# Altium schematic sheet RAM.SchDoc
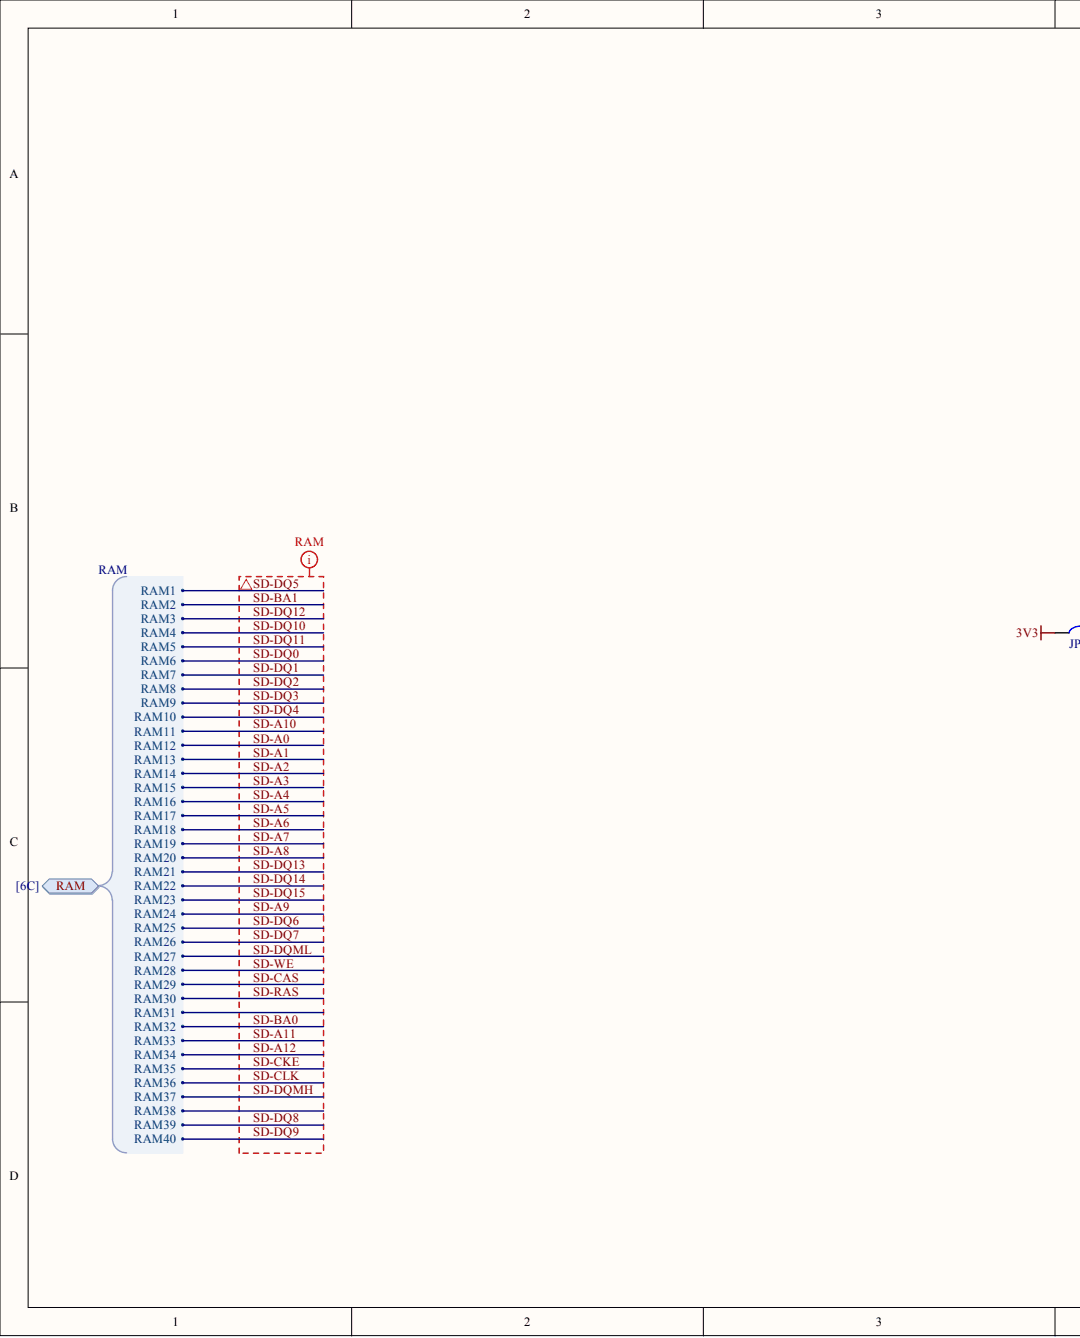
[diagram p0: RAM.SchDoc, left]
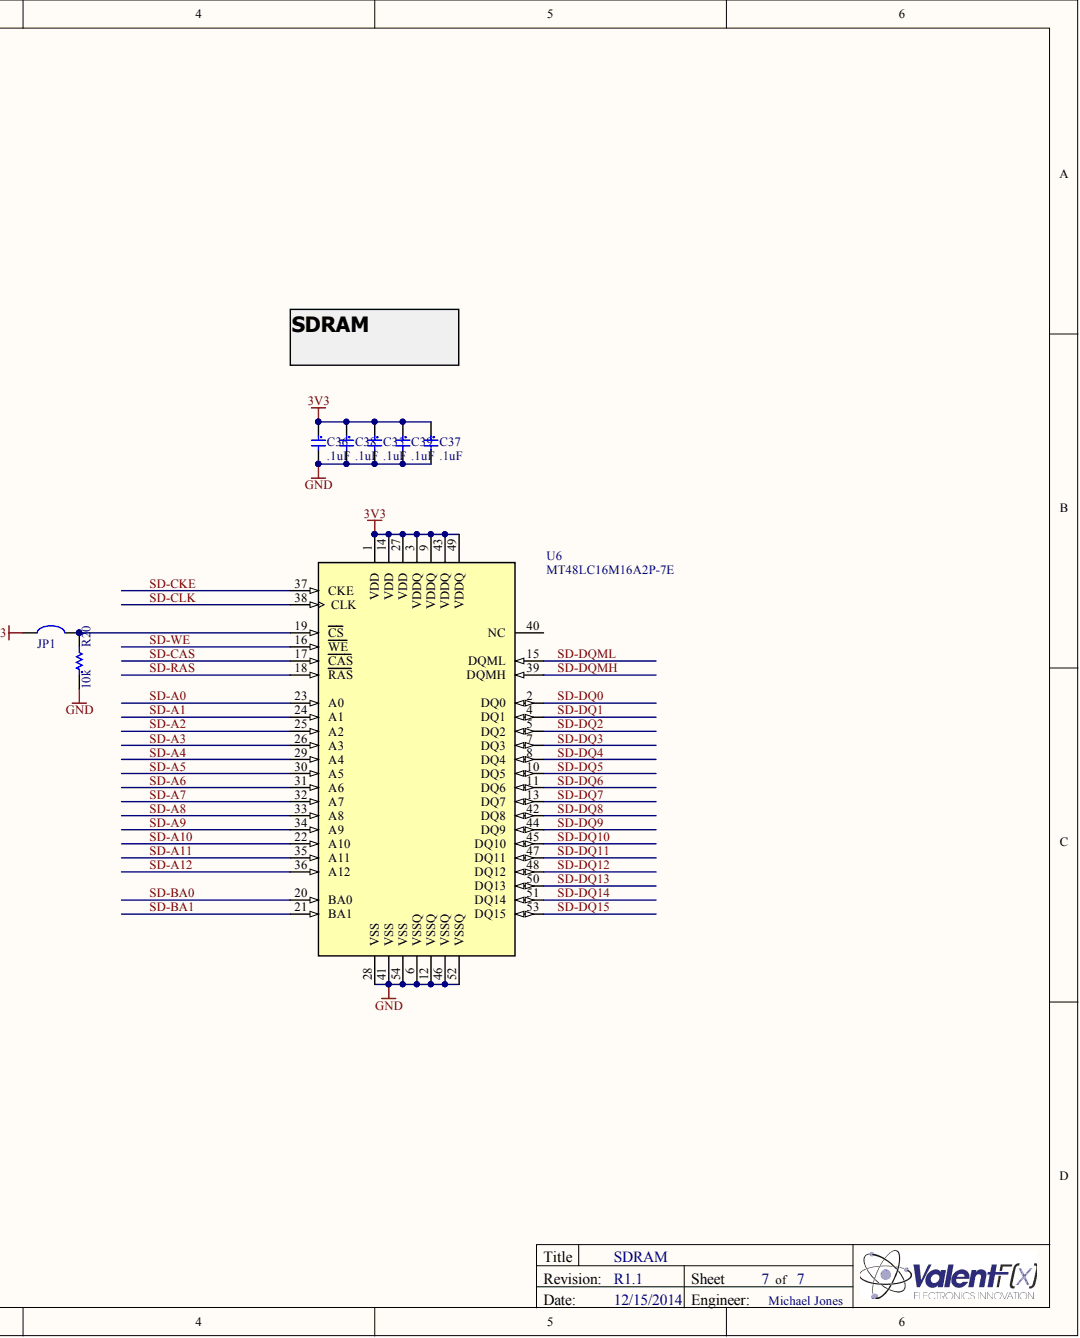
[diagram p1: RAM.SchDoc, right]

## Parts
C35  .1uF  [c0603]
C36  .1uF  [c0603]
C37  .1uF  [c0603]
C38  .1uF  [c0603]
C39  .1uF  [c0603]
JP1  Solder Jumper  [SOLDER_JUMPER]  (value not drawn)  (no BOM)
R20  10k  [R0603]
U6  MT48LC16M16A2P-7E  [TSOP2-54_L]

## Ports
RAM, RAM.RAM1, RAM.RAM2, RAM.RAM3, RAM.RAM4, RAM.RAM5, RAM.RAM6, RAM.RAM7, RAM.RAM8, RAM.RAM9, RAM.RAM10, RAM.RAM11, RAM.RAM12, RAM.RAM13, RAM.RAM14, RAM.RAM15, RAM.RAM16, RAM.RAM17, RAM.RAM18, RAM.RAM19, RAM.RAM20, RAM.RAM21, RAM.RAM22, RAM.RAM23, RAM.RAM24, RAM.RAM25, RAM.RAM26, RAM.RAM27, RAM.RAM28, RAM.RAM29, RAM.RAM30, RAM.RAM31, RAM.RAM32, RAM.RAM33, RAM.RAM34, RAM.RAM35, RAM.RAM36, RAM.RAM37, RAM.RAM38, RAM.RAM39, RAM.RAM40

## Nets
3V3: C35.1 C36.1 C37.1 C38.1 C39.1 JP1.1 U6.1(VDD) U6.3(VDDQ) U6.9(VDDQ) U6.14(VDD) U6.27(VDD) U6.43(VDDQ) U6.49(VDDQ)
GND: C35.2 C36.2 C37.2 C38.2 C39.2 R20.1 U6.6(VSSQ) U6.12(VSSQ) U6.28(VSS) U6.41(VSS) U6.46(VSSQ) U6.52(VSSQ) U6.54(VSS)
SD-A0: U6.23(A0) ; port RAM
SD-A1: U6.24(A1) ; port RAM
SD-A2: U6.25(A2) ; port RAM
SD-A3: U6.26(A3) ; port RAM
SD-A4: U6.29(A4) ; port RAM
SD-A5: U6.30(A5) ; port RAM
SD-A6: U6.31(A6) ; port RAM
SD-A7: U6.32(A7) ; port RAM
SD-A8: U6.33(A8) ; port RAM
SD-A9: U6.34(A9) ; port RAM
SD-A10: U6.22(A10) ; port RAM
SD-A11: U6.35(A11) ; port RAM
SD-A12: U6.36(A12) ; port RAM
SD-BA0: U6.20(BA0) ; port RAM
SD-BA1: U6.21(BA1) ; port RAM
SD-CAS: U6.17(CAS) ; port RAM
SD-CKE: U6.37(CKE) ; port RAM
SD-CLK: U6.38(CLK) ; port RAM
SD-DQ0: U6.2(DQ0) ; port RAM
SD-DQ1: U6.4(DQ1) ; port RAM
SD-DQ2: U6.5(DQ2) ; port RAM
SD-DQ3: U6.7(DQ3) ; port RAM
SD-DQ4: U6.8(DQ4) ; port RAM
SD-DQ5: U6.10(DQ5) ; port RAM
SD-DQ6: U6.11(DQ6) ; port RAM
SD-DQ7: U6.13(DQ7) ; port RAM
SD-DQ8: U6.42(DQ8) ; port RAM
SD-DQ9: U6.44(DQ9) ; port RAM
SD-DQ10: U6.45(DQ10) ; port RAM
SD-DQ11: U6.47(DQ11) ; port RAM
SD-DQ12: U6.48(DQ12) ; port RAM
SD-DQ13: U6.50(DQ13) ; port RAM
SD-DQ14: U6.51(DQ14) ; port RAM
SD-DQ15: U6.53(DQ15) ; port RAM
SD-DQMH: U6.39(DQMH) ; port RAM
SD-DQML: U6.15(DQML) ; port RAM
SD-RAS: U6.18(RAS) ; port RAM
SD-WE: U6.16(WE) ; port RAM
NetJP1_2: JP1.2 R20.2 U6.19(CS)
N00290:
N00291: ; port RAM
N00292: ; port RAM

Not connected: U6 40(NC)

## Library data of the parts
C35-C39 = C0603 - CL10F104ZA8NNNC: CAP CER 0.1UF 25V Y5V 0603; Manufacturer: Samsung Electro-Mechanics America, Inc; Accept Alternative: Yes; Capacitance: 0.1µF; Temperature Coefficient: Y5V (F); Tolerance: -20%, +80%; Applications: General Purpose; Category: Capacitors; Component Kind: Standard; ComponentLink1Description: http://www.samsungsem.com/servlet/FileDownload?type=spec&file=CL10F104ZA8NNNC.pdf; ComponentLink1URL: http://www.samsungsem.com/servlet/FileDownload?type=spec&file=CL10F104ZA8NNNC.pdf; Features: -; Height - Seated (Max): -; Lead Spacing: -; Lead Style: -; Library Name: mCapacitors.SchLib; Manufacturer Part Number: CL10F104ZA8NNNC; Mounting Type: Surface Mount, MLCC; Operating Temperature: -30°C ~ 85°C; Package / Case: 0603 (1608 Metric); Packaging: Cut Tape (CT); Pricing 1: 1=0.1, 10=0.017, 25=0.012, 50=0.009, 100=0.0076, 250=0.00624, 500=0.00536, 1000=0.00417 (USD); Ratings: -; RoHS: RoHS Compliant; Size / Dimension: 0.063" L x 0.031" W (1.60mm x 0.80mm); Stock 1: 400907; Supplier 1: Digi-Key; Supplier Part Number 1: 1276-1011-1-ND; Thickness (Max): 0.035" (0.90mm); Value: .1uF; Voltage - Rated: 25V
JP1 = Solder Jumper: Solder/Configuration Jumper; Library Name: mConnector.SchLib; Manufacturer: n/a; Manufacturer Part Number: n/a; ROHS Certificate: n/a; Source: n/a; Source Part Number: n/a
R20 = R0603 - CRCW060310K0FKEA: 10k Thick Film Resistors - SMD 1/10watt 10Kohms 1%; Manufacturer: Vishay Dale; Power (Watts): 0.1W, 1/10W; Temperature Coefficient: ±100ppm/°C; Category: Resistors; Component Kind: Standard; ComponentLink1Description: http://www.vishay.com/doc?20035; ComponentLink1URL: http://www.vishay.com/doc?20035; Composition: Thick Film; Features: -; Height: 0.020" (0.50mm); Library Name: mResistors.SchLib; Manufacturer Part Number: CRCW060310K0FKEA; Number of Terminations: 2; Package / Case: 0603 (1608 Metric); Packaging: Cut Tape (CT); Pricing 1: 10=0.081, 50=0.0436, 200=0.0251, 1000=0.01711 (USD); Resistance (Ohms): 10K; RoHS: RoHS Compliant; Size / Dimension: 0.061" L x 0.033" W (1.55mm x 0.85mm); Stock 1: 997856; Supplier 1: Digi-Key; Supplier Part Number 1: 541-10.0KHCT-ND; Tolerance: ±1%; Value: 10k
U6 = SDRAM - MT48LC16M16A2P-7E: 256Mb Synchronous High-Speed CMOS DRAM, 16Mb x 16Bit x 4 Banks, 54-Pin TSOP Pb-free, Commercial; Manufacturer: MICRON; Manufacturer Part Number: MT48LC16M16A2P-7E IT:G; Component Kind: Standard; ComponentLink1Description: http://avnetexpress.avnet.com/store/em/EMController?langId=-1&storeId=500201&catalogId=500201&term=mt48lc16m16a2p&x=-860&y=-339&searchType=&advAction=&N=0&Ne=100000&action=products; ComponentLink1URL: http://avnetexpress.avnet.com/store/em/EMController?langId=-1&storeId=500201&catalogId=500201&term=mt48lc16m16a2p&x=-860&y=-339&searchType=&advAction=&N=0&Ne=100000&action=products; Library Name: mIC.SchLib; Pricing 1: 1=6.65, 100=4.68, 1000=4.22 (USD); RoHS: YES; Stock 1: 662; Supplier 1: Avnet; Supplier Part Number 1: MT48LC16M16A2P-7E IT:G; Value: MT48LC16M16A2P-7E
